# Altium schematic sheet 04_ATK-DLMP257_4G_HUB.SchDoc
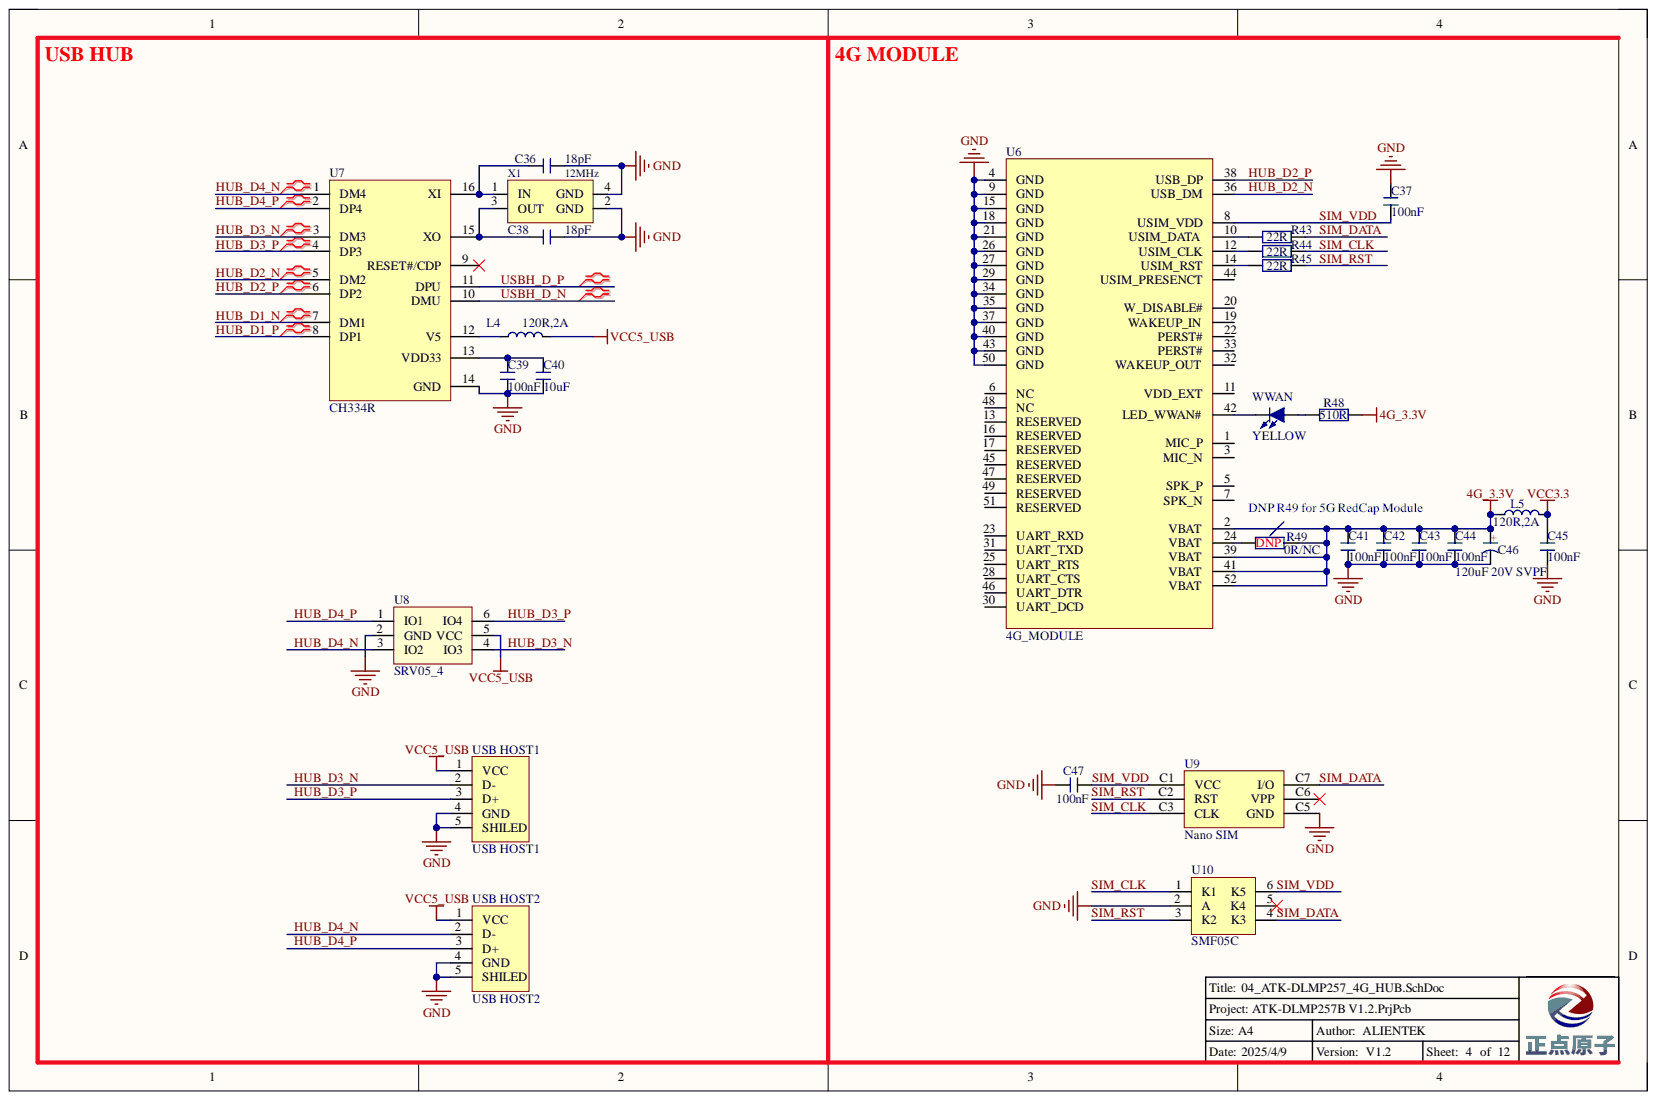
[diagram p0: 04_ATK-DLMP257_4G_HUB.SchDoc]

## Parts
C36  18pF  [C 0603]
C37  100nF  [C 0603]
C38  18pF  [C 0603]
C39  100nF  [C 0603]
C40  10uF  [C 0603]
C41  100nF  [C 0603]
C42  100nF  [C 0603]
C43  100nF  [C 0603]
C44  100nF  [C 0603]
C45  100nF  [C 0603]
C46  120uF 20V SVPF  [CM D(6.3*7.7)]
C47  100nF  [C 0603]
L4  120R,2A  [L 0603]
L5  120R,2A  [L 0603]
R43  22R  [R 0603]
R44  22R  [R 0603]
R45  22R  [R 0603]
R48  510R  [R 0603]
R49  0R/NC  [R 0603]
U6  4G_MODULE  [Mini PCIE 52P]
U7  CH334R  [QSOP16]
U8  SRV05_4  [SOT23-6L]
U9  Nano SIM  [Nano SIM 7P]
U10  SMF05C  [SOT363-6N]
USB_HOST1  USB HOST1  [USB-A/S_E]
USB_HOST2  USB HOST2  [USB-A/S_E]
WWAN  YELLOW  [LED 0805G]
X1  12MHz  [XTAL-3225]

## Nets
4G_3.3V: C41.2 C42.2 C43.2 C44.2 C46.1 L5.1 R48.2 R49.2 U6.2(VBAT) U6.39(VBAT) U6.41(VBAT) U6.52(VBAT)
GND: C36.2 C37.2 C38.2 C39.1 C40.1 C41.1 C42.1 C43.1 C44.1 C45.1 C46.2 C47.2 U6.4(GND) U6.9(GND) U6.15(GND) U6.18(GND) U6.21(GND) U6.26(GND) U6.27(GND) U6.29(GND) U6.34(GND) U6.35(GND) U6.37(GND) U6.40(GND) U6.43(GND) U6.50(GND) U7.14(GND) U8.2(GND) U9.C5(GND) U10.2(A) USB_HOST1.4(GND) USB_HOST1.5(SHILED) USB_HOST2.4(GND) USB_HOST2.5(SHILED) X1.2(GND) X1.4(GND)
VCC3.3: C45.2 L5.2
VCC5_USB: L4.2 U8.5(VCC) USB_HOST1.1(VCC) USB_HOST2.1(VCC)
HUB_D1_N: U7.7(DM1)
HUB_D1_P: U7.8(DP1)
HUB_D2_N: U6.36(USB_DM) U7.5(DM2)
HUB_D2_P: U6.38(USB_DP) U7.6(DP2)
HUB_D3_N: U7.3(DM3) U8.4(IO3) USB_HOST1.2(D-)
HUB_D3_P: U7.4(DP3) U8.6(IO4) USB_HOST1.3(D+)
HUB_D4_N: U7.1(DM4) U8.3(IO2) USB_HOST2.2(D-)
HUB_D4_P: U7.2(DP4) U8.1(IO1) USB_HOST2.3(D+)
SIM_CLK: R44.2 U9.C3(CLK) U10.1(K1)
SIM_DATA: R43.2 U9.C7(I/O) U10.4(K3)
SIM_RST: R45.2 U9.C2(RST) U10.3(K2)
SIM_VDD: C37.1 C47.1 U6.8(USIM_VDD) U9.C1(VCC) U10.6(K5)
USBH_D_N: U7.10(DMU)
USBH_D_P: U7.11(DPU)
NetC36_1: C36.1 U7.16(XI) X1.1(IN)
NetC38_1: C38.1 U7.15(XO) X1.3(OUT)
NetC39_2: C39.2 C40.2 U7.13(VDD33)
NetL4_1: L4.1 U7.12(V5)
NetR43_1: R43.1 U6.10(USIM_DATA)
NetR44_1: R44.1 U6.12(USIM_CLK)
NetR45_1: R45.1 U6.14(USIM_RST)
NetR48_1: R48.1 WWAN.1
NetR49_1: R49.1 U6.24(VBAT)
NetU6_42: U6.42(LED_WWAN#) WWAN.2

Not connected: U6 1(MIC_P) 3(MIC_N) 5(SPK_P) 6(NC) 7(SPK_N) 11(VDD_EXT) 13(RESERVED) 16(RESERVED) 17(RESERVED) 19(WAKEUP_IN) 20(W_DISABLE#) 22(PERST#) 23(UART_RXD) 25(UART_RTS) 28(UART_CTS) 30(UART_DCD) 31(UART_TXD) 32(WAKEUP_OUT) 33(PERST#) 44(USIM_PRESENCT) 45(RESERVED) 46(UART_DTR) 47(RESERVED) 48(NC) 49(RESERVED) 51(RESERVED); U7 9(RESET#/CDP); U9 C6(VPP); U10 5(K4)

## Library data of the parts
C36 C38-C40 = C: 无极性贴片电容; 版权归属: 源创客; BOM名称: 无极性贴片电容; Component Kind: Standard; 学习资源: www.ooocke.com; Library Name: Lycreturn.IntLib
C37 C41-C45 C47 = C: 无极性贴片电容; 版权归属: 正点原子; BOM名称: 无极性贴片电容; Component Kind: Standard; 学习资源: www.openedv.com; Library Name: Lycreturn.IntLib
C46 = C-CM: 贴片电解电容; 版权归属: 源创客; BOM名称: 贴片电解电容; Component Kind: Standard; Library Name: 电阻-电容-电感.SchLib; 学习资源: www.ooocke.com
L4 L5 = L: 小功率贴片电感磁珠; 版权归属: 正点原子; BOM名称: 小功率贴片电感; Component Kind: Standard; Library Name: 电阻-电容-电感.SchLib; 学习资源: www.openedv.com
R43-R45 R48 = R: 贴片电阻; 版权归属: 正点原子; BOM名称: 贴片电阻; Component Kind: Standard; 学习资源: www.openedv.com; Library Name: Lycreturn.IntLib
R49 = R: 电阻; BOM名称: 贴片电阻; 版权归属: 正点原子; Component Kind: Standard; Library Name: 正点原子封装库V1.0.IntLib; Parameter: 电阻; 学习资源: www.openedv.com
U6 = 4G-EC20: Component Kind: Standard; Library Name: 模块.SchLib
U8 = SRV05_4: ESD保护二极管阵列; Component Kind: Standard; Library Name: 二极管-整流桥.SchLib
U10 = SMF05C: 5线TVS管; Parameter: 芯片; 版权归属: 正点原子; Component Kind: Standard; Library Name: 正点原子封装库V1.0.IntLib; 学习资源: www.openedv.com
USB_HOST1 USB_HOST2 = USB-A/S: USB-A型母接口; 版权归属: 源创客; BOM名称: USB-A型母接口; Component Kind: Standard; Library Name: 接口类.SchLib; 学习资源: www.ooocke.com
WWAN = LED-SMD: 贴片LED; 版权归属: 正点原子; BOM名称: 贴片LED; Component Kind: Standard; 学习资源: www.openedv.com; Library Name: Lycreturn.IntLib
X1 = XTAL-4P: 4脚无源晶振; BOM名称: 4脚晶振; 版权归属: 源创客; Component Kind: Standard; 学习资源: www.ooocke.com; Library Name: Lycreturn.IntLib
U7 = CH334R: Component Kind: Standard; Library Name: ZZK_COMPONENT.IntLib
U9 = Nano SIM: Component Kind: Standard; Library Name: ZZK_COMPONENT.IntLib
